# Altium schematic sheet 09_memory.SchDoc(09_memory)
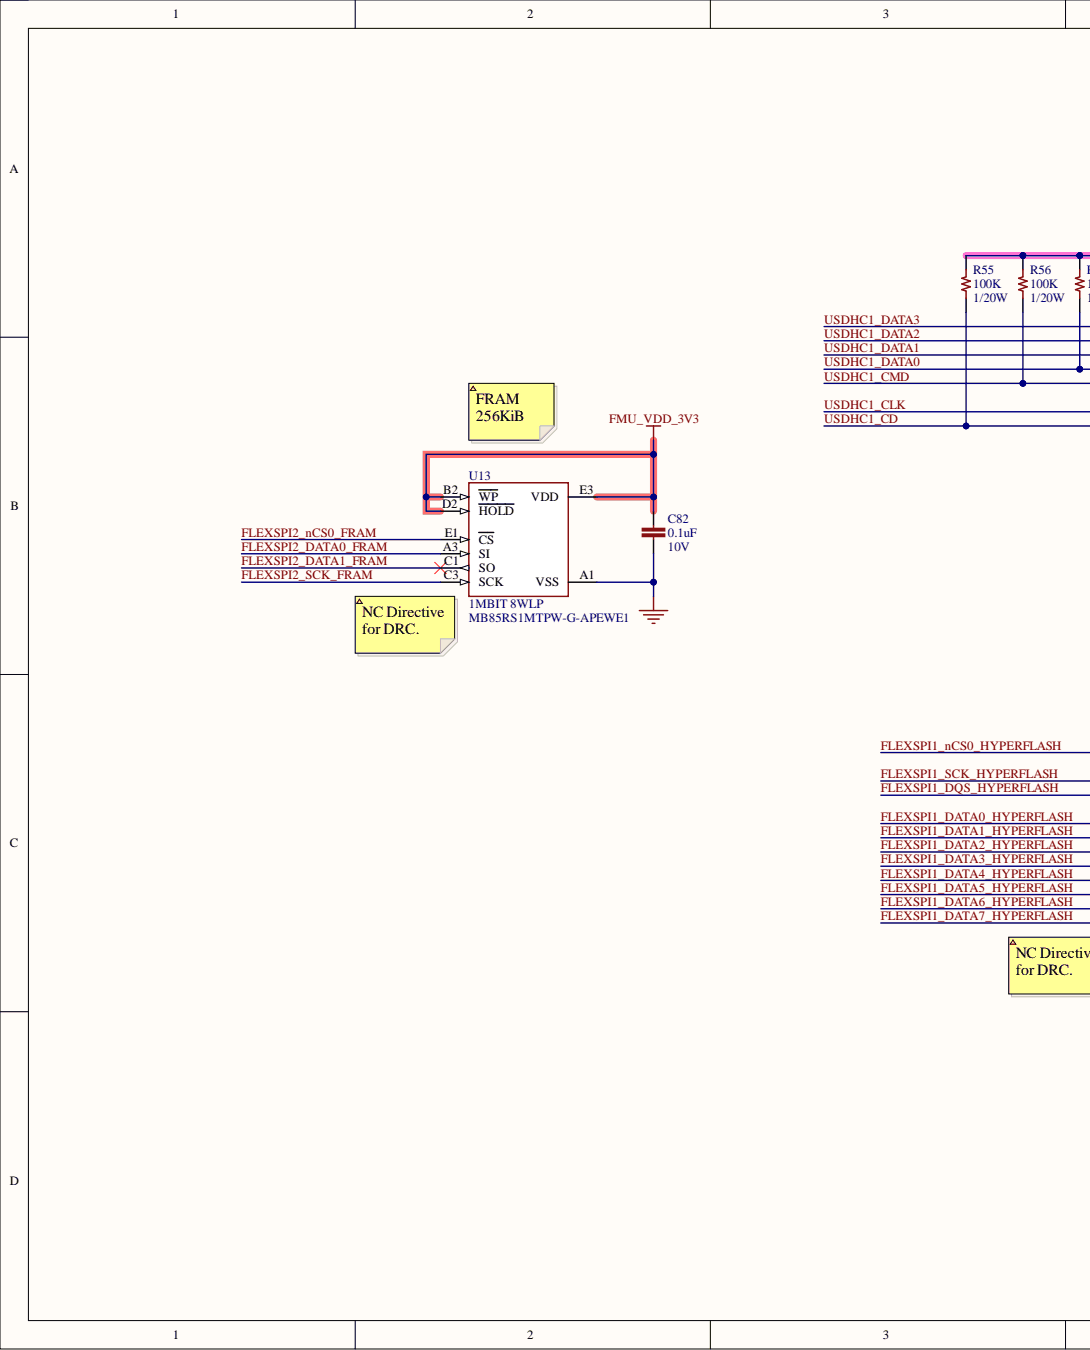
[diagram p0: 09_memory.SchDoc, left]
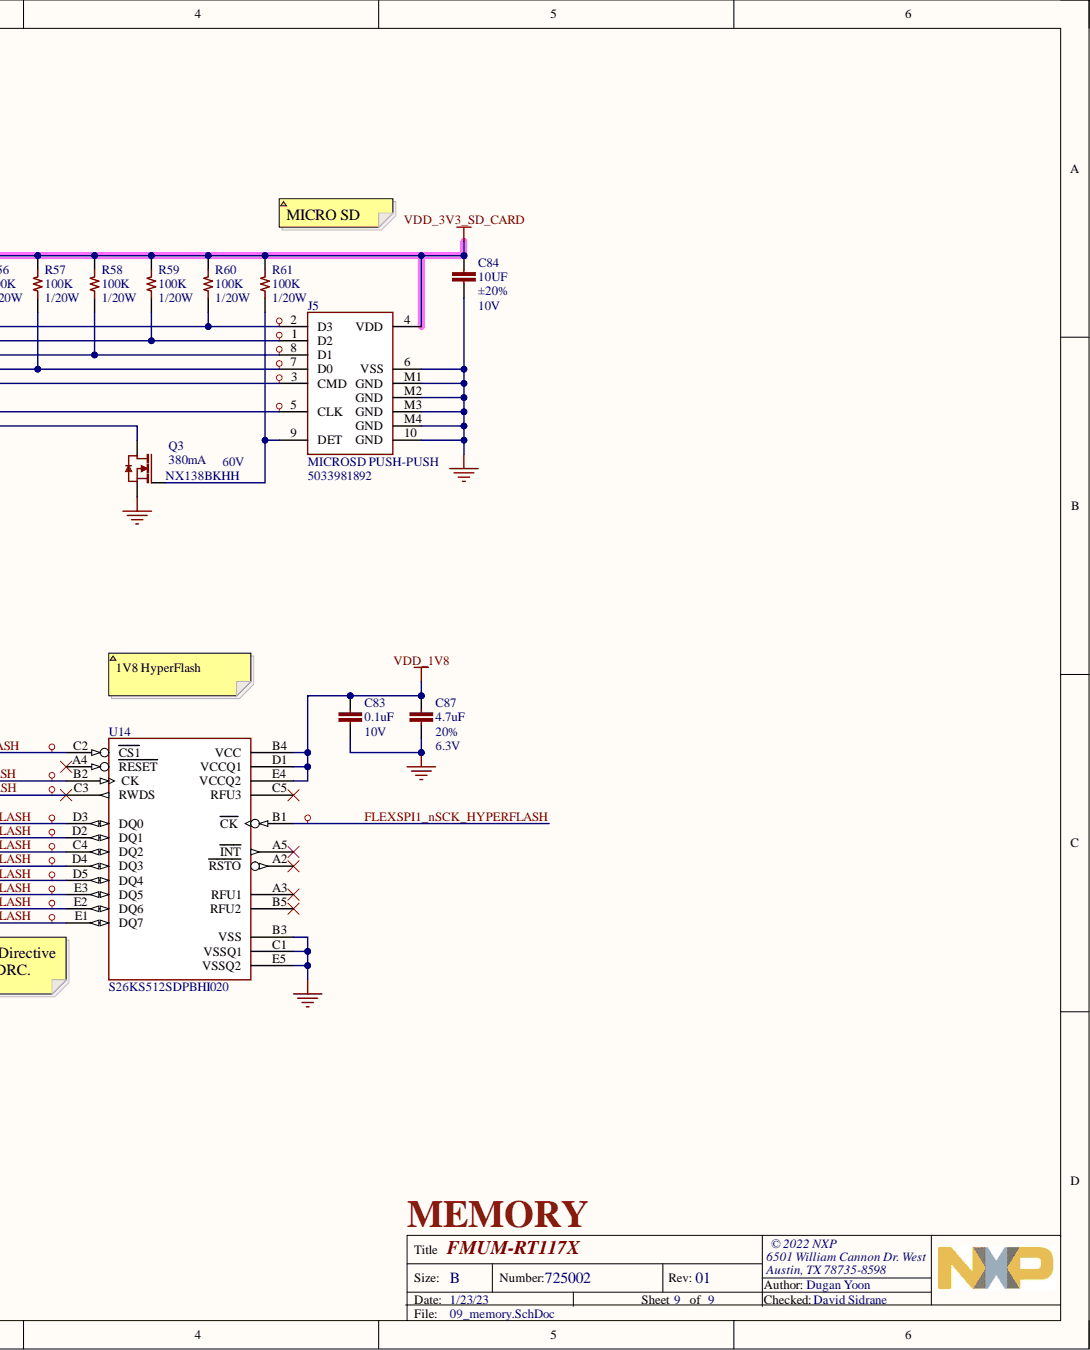
[diagram p1: 09_memory.SchDoc, right]

## Parts
C82  value 0.1uF  Voltage - Rated: 10V  [CAPC60X30X33L15N]  (hidden comment: CAP CER 0.1uF 20% 10V X5R 0201)
C83  value 0.1uF  Voltage - Rated: 10V  [CAPC60X30X33L15N]  (hidden comment: CAP CER 0.1uF 20% 10V X5R 0201)
C84  value 10UF  Tolerance: ±20%  Voltage - Rated: 10V  [CAPC100X50X56L25N]  (hidden comment: CAP CER 10UF 20% 10V X5R 0402)
C87  value 4.7uF  Tolerance: 20%  Voltage - Rated: 6.3V  [CAPC60X30X33L15N]  (hidden comment: CAP CER 4.7uF 20% 10V X5R 0201)
J5  ID: MICROSD PUSH-PUSH  Manufacturer Part Number: 5033981892  [MOLEX_503398-1892_NO_KEEPOUT]  (hidden comment: CONN RCPT 9POS MICROSD PUSH/PUSH R/A SMD)
Q3  Current: 380mA  Manufacturer Part Number: NX138BKHH  [TRXDFN3_62X62X40L15X15N]  (hidden comment: TRANSISTOR N-CH 60V 380MA DFN0606-3)
R55  value 100K  Power: 1/20W  [RESC60X30X33L15N]  (hidden comment: RES SMD 100K OHM 1% 1/20W 0201)
R56  value 100K  Power: 1/20W  [RESC60X30X33L15N]  (hidden comment: RES SMD 100K OHM 1% 1/20W 0201)
R57  value 100K  Power: 1/20W  [RESC60X30X33L15N]  (hidden comment: RES SMD 100K OHM 1% 1/20W 0201)
R58  value 100K  Power: 1/20W  [RESC60X30X33L15N]  (hidden comment: RES SMD 100K OHM 1% 1/20W 0201)
R59  value 100K  Power: 1/20W  [RESC60X30X33L15N]  (hidden comment: RES SMD 100K OHM 1% 1/20W 0201)
R60  value 100K  Power: 1/20W  [RESC60X30X33L15N]  (hidden comment: RES SMD 100K OHM 1% 1/20W 0201)
R61  value 100K  Power: 1/20W  [RESC60X30X33L15N]  (hidden comment: RES SMD 100K OHM 1% 1/20W 0201)
U13  ID: 1MBIT 8WLP  Manufacturer Part Number: MB85RS1MTPW-G-APEWE1  [FUJITSU_WLP-08P-M01]  (hidden comment: IC FRAM 1MBIT SPI 40MHZ 8WLP)
U14  Manufacturer Part Number: S26KS512SDPBHI020  [BGA24CP100_5X5_800X600X100B40N]  (hidden comment: IC FLASH 512MBIT PARALLEL 24FBGA)

## Nets
FMU_VDD_3V3: C82.1 U13.B2(WP) U13.D2(HOLD) U13.E3(VDD)
GND: C82.2 C83.2 C84.2 C87.2 J5.6(VSS) J5.10(GND) J5.M1(GND) J5.M2(GND) J5.M3(GND) J5.M4(GND) Q3.2 U13.A1(VSS) U14.B3(VSS) U14.C1(VSSQ1) U14.E5(VSSQ2)
VDD_1V8: C83.1 C87.1 U14.B4(VCC) U14.D1(VCCQ1) U14.E4(VCCQ2)
VDD_3V3_SD_CARD: C84.1 J5.4(VDD) R55.1 R56.1 R57.1 R58.1 R59.1 R60.1 R61.1
FLEXSPI1_DATA0_HYPERFLASH: U14.D3(DQ0)
FLEXSPI1_DATA1_HYPERFLASH: U14.D2(DQ1)
FLEXSPI1_DATA2_HYPERFLASH: U14.C4(DQ2)
FLEXSPI1_DATA3_HYPERFLASH: U14.D4(DQ3)
FLEXSPI1_DATA4_HYPERFLASH: U14.D5(DQ4)
FLEXSPI1_DATA5_HYPERFLASH: U14.E3(DQ5)
FLEXSPI1_DATA6_HYPERFLASH: U14.E2(DQ6)
FLEXSPI1_DATA7_HYPERFLASH: U14.E1(DQ7)
FLEXSPI1_DQS_HYPERFLASH: U14.C3(RWDS)
FLEXSPI1_nCS0_HYPERFLASH: U14.C2(CS1)
FLEXSPI1_nSCK_HYPERFLASH: U14.B1(CK)
FLEXSPI1_SCK_HYPERFLASH: U14.B2(CK)
FLEXSPI2_DATA0_FRAM: U13.A3(SI)
FLEXSPI2_DATA1_FRAM: U13.C1(SO)
FLEXSPI2_nCS0_FRAM: U13.E1(CS)
FLEXSPI2_SCK_FRAM: U13.C3(SCK)
USDHC1_CD: Q3.3 R55.2
USDHC1_CLK: J5.5(CLK)
USDHC1_CMD: J5.3(CMD) R56.2
USDHC1_DATA0: J5.7(D0) R57.2
USDHC1_DATA1: J5.8(D1) R58.2
USDHC1_DATA2: J5.1(D2) R59.2
USDHC1_DATA3: J5.2(D3) R60.2
NetJ5_9: J5.9(DET) Q3.1 R61.2

Not connected: U14 A2(RSTO) A3(RFU1) A4(RESET) A5(INT) B5(RFU2) C5(RFU3)

## Library data of the parts
C82 C83 = CAP_0.1uF_10V_X5R_0201: Manufacturer: Murata Electronics; Manufacturer Part Number: GRM033R61A104ME15D; Category: Capacitors; Component Kind: Standard; ComponentLink1Description: https://www.digikey.com/en/products/detail/murata-electronics/GRM033R61A104ME15D/2175191; ComponentLink1URL: https://www.digikey.com/en/products/detail/murata-electronics/GRM033R61A104ME15D/2175191; Description: CAP CER 0.1uF 20% 10V X5R 0201; Operating Temperature: -55°C ~ 85°C; Packaging: Cut Tape (CT); Part Status: Active; Pricing 1: 1=0.10 (USD); Stock 1: 12909013; Supplier 1: Digi-Key; Supplier Part Number 1: 490-5405-1-ND; Tolerance: 20%; Library Name: NscDG_Integrated_Library.SchLib
C84 = CAP_10UF_20%_10V_X5R_0402: Manufacturer: Samsung Electro-Mechanics; Manufacturer Part Number: CL05A106MP5NUNC; Category: Capacitors; Component Kind: Standard; ComponentLink1Description: https://www.digikey.com/products/en?keywords=%091276-1450-1-ND; ComponentLink1URL: https://www.digikey.com/products/en?keywords=%091276-1450-1-ND; Description: CAP CER 10UF 20% 10V X5R 0402; Operating Temperature: -55°C ~ 85°C; Packaging: Tape & Reel (TR); Part Status: Active; Pricing 1: 1=0.53, 10=0.373, 100=0.23, 500=0.1761, 1000=0.15094, 2500=0.14375, 5000=0.13656 (USD); Ratings: -; Stock 1: 0; Supplier 1: Digi-Key; Supplier Part Number 1: 1276-1450-1-ND; Temperature Coefficient: X5R; Library Name: NscDG_Integrated_Library.SchLib
C87 = CAP_4.7uF_6.3V_X5R_0201: Manufacturer: Murata Electronics; Manufacturer Part Number: GRM035R60J475ME15D; Category: Capacitors; Component Kind: Standard; ComponentLink1Description: https://www.digikey.com/en/products/detail/murata-electronics/GRM035R60J475ME15D/5877393; ComponentLink1URL: https://www.digikey.com/en/products/detail/murata-electronics/GRM035R60J475ME15D/5877393; Description: CAP CER 4.7uF 20% 10V X5R 0201; Operating Temperature: -55°C ~ 85°C; Packaging: Cut Tape (CT); Part Status: Active; Pricing 1: 1=0.24 (USD); Stock 1: 73400; Supplier 1: Digi-Key; Supplier Part Number 1: 490-13230-1-ND; Library Name: NscDG_Integrated_Library.SchLib
J5 = CONN_RCPT_9POS_MICROSD_PUSH-PUSH_RA_SMD: Manufacturer: Molex; System: Connector; Category: Connectors, Interconnects; Component Kind: Standard; ComponentLink1Description: https://www.digikey.com/products/en/connectors-interconnects/memory-connectors-pc-card-sockets/414?k=5033981892&k=&pkeyword=5033981892&sv=0&pv7=2&sf=0&quantity=&ColumnSort=0&page=1&pageSize=25; ComponentLink1URL: https://www.digikey.com/products/en/connectors-interconnects/memory-connectors-pc-card-sockets/414?k=5033981892&k=&pkeyword=5033981892&sv=0&pv7=2&sf=0&quantity=&ColumnSort=0&page=1&pageSize=25; Description: CONN RCPT 9POS MICROSD PUSH/PUSH R/A SMD; Operating Temperature: -25°C to +85°C; Packaging: Cut Tape (CT); Part Status: Active; Pricing 1: 1=2.65 (USD); Stock 1: 15139; Supplier 1: Digi-Key; Supplier Part Number 1: WM11190CT-ND; Library Name: NscDG_Integrated_Library.SchLib
Q3 = TRAN_MOSFET_N-CH_NX138BKHH_DFN0606-3: Manufacturer: Nexperia USA Inc.; Category: Discrete Semiconductor Products; Component Kind: Standard; ComponentLink1Description: https://www.digikey.com/en/products/detail/nexperia-usa-inc/NX138BKHH/13575152; ComponentLink1URL: https://www.digikey.com/en/products/detail/nexperia-usa-inc/NX138BKHH/13575152; Description: TRANSISTOR N-CH 60V 380MA DFN0606-3; Packaging: Cut Tape (CT); Part Status: Active; Pricing 1: 1=0.28 (USD); Stock 1: 9500; Supplier 1: Digi-Key; Supplier Part Number 1: 1727-NX138BKHHCT-ND; Voltage: 60V; Library Name: NscDG_Integrated_Library.SchLib
R55-R61 = RES_100K_1%_1/20W_0201: Manufacturer: Yageo; Manufacturer Part Number: RC0201FR-07100KL; Category: Resistors; Component Kind: Standard; ComponentLink1Description: https://www.digikey.com/en/products/detail/yageo/RC0201FR-07100KL/1948859; ComponentLink1URL: https://www.digikey.com/en/products/detail/yageo/RC0201FR-07100KL/1948859; Description: RES SMD 100K OHM 1% 1/20W 0201; Operating Temperature: -55°C ~ 125°C; Packaging: Cut Tape (CT); Part Status: Active; Pricing 1: 1=0.1, 10=0.014, 100=0.0056, 1000=0.00253, 2500=0.0022, 5000=0.00181 (USD); Stock 1: 6175300; Supplier 1: Digi-Key; Supplier Part Number 1: 311-100KMCT-ND; Tolerance: ±1%; Library Name: NscDG_Integrated_Library.SchLib
U13 = IC_FRAM_1MBIT-8WLP: Manufacturer: Kaga FEI America, Inc.; Category: Integrated Circuits (ICs); Component Kind: Standard; ComponentLink1Description: https://www.digikey.com/en/products/detail/kaga-fei-america-inc/MB85RS1MTPW-G-APEWE1/5456438?s=N4IgTCBcDaILICEAcBWASgZQIxwCoAUB1AWgHFiBBfAUUOqxAF0BfIA; ComponentLink1URL: https://www.digikey.com/en/products/detail/kaga-fei-america-inc/MB85RS1MTPW-G-APEWE1/5456438?s=N4IgTCBcDaILICEAcBWASgZQIxwCoAUB1AWgHFiBBfAUUOqxAF0BfIA; Description: IC FRAM 1MBIT SPI 40MHZ 8WLP; Operating Temperature: -40°C ~ 85°C (TA); Packaging: Cut Tape (CT); Part Status: Active; Pricing 1: 1=6.51 (USD); Stock 1: 574; Supplier 1: Digi-Key; Supplier Part Number 1: 865-1272-1-ND; Library Name: NscDG_Integrated_Library.SchLib
U14 = IC_S26KS512SDPBHI020_24FBGA: Manufacturer: Cypress Semiconductor Corp; Category: Integrated Circuits (ICs); Component Kind: Standard; ComponentLink1Description: https://www.digikey.com/en/products/detail/cypress-semiconductor-corp/S26KS512SDPBHI020/6057101; ComponentLink1URL: https://www.digikey.com/en/products/detail/cypress-semiconductor-corp/S26KS512SDPBHI020/6057101; Description: IC FLASH 512MBIT PARALLEL 24FBGA; Operating Temperature: -40°C ~ 85°C (TA); Packaging: Tray; Part Status: Active; Pricing 1: 1=14.47 (USD); Stock 1: 1487; Supplier 1: Digikey; Supplier Part Number 1: 428-3603-ND; Library Name: NscDG_Integrated_Library.SchLib
